# Altium schematic sheet 3xInputs.SchDoc
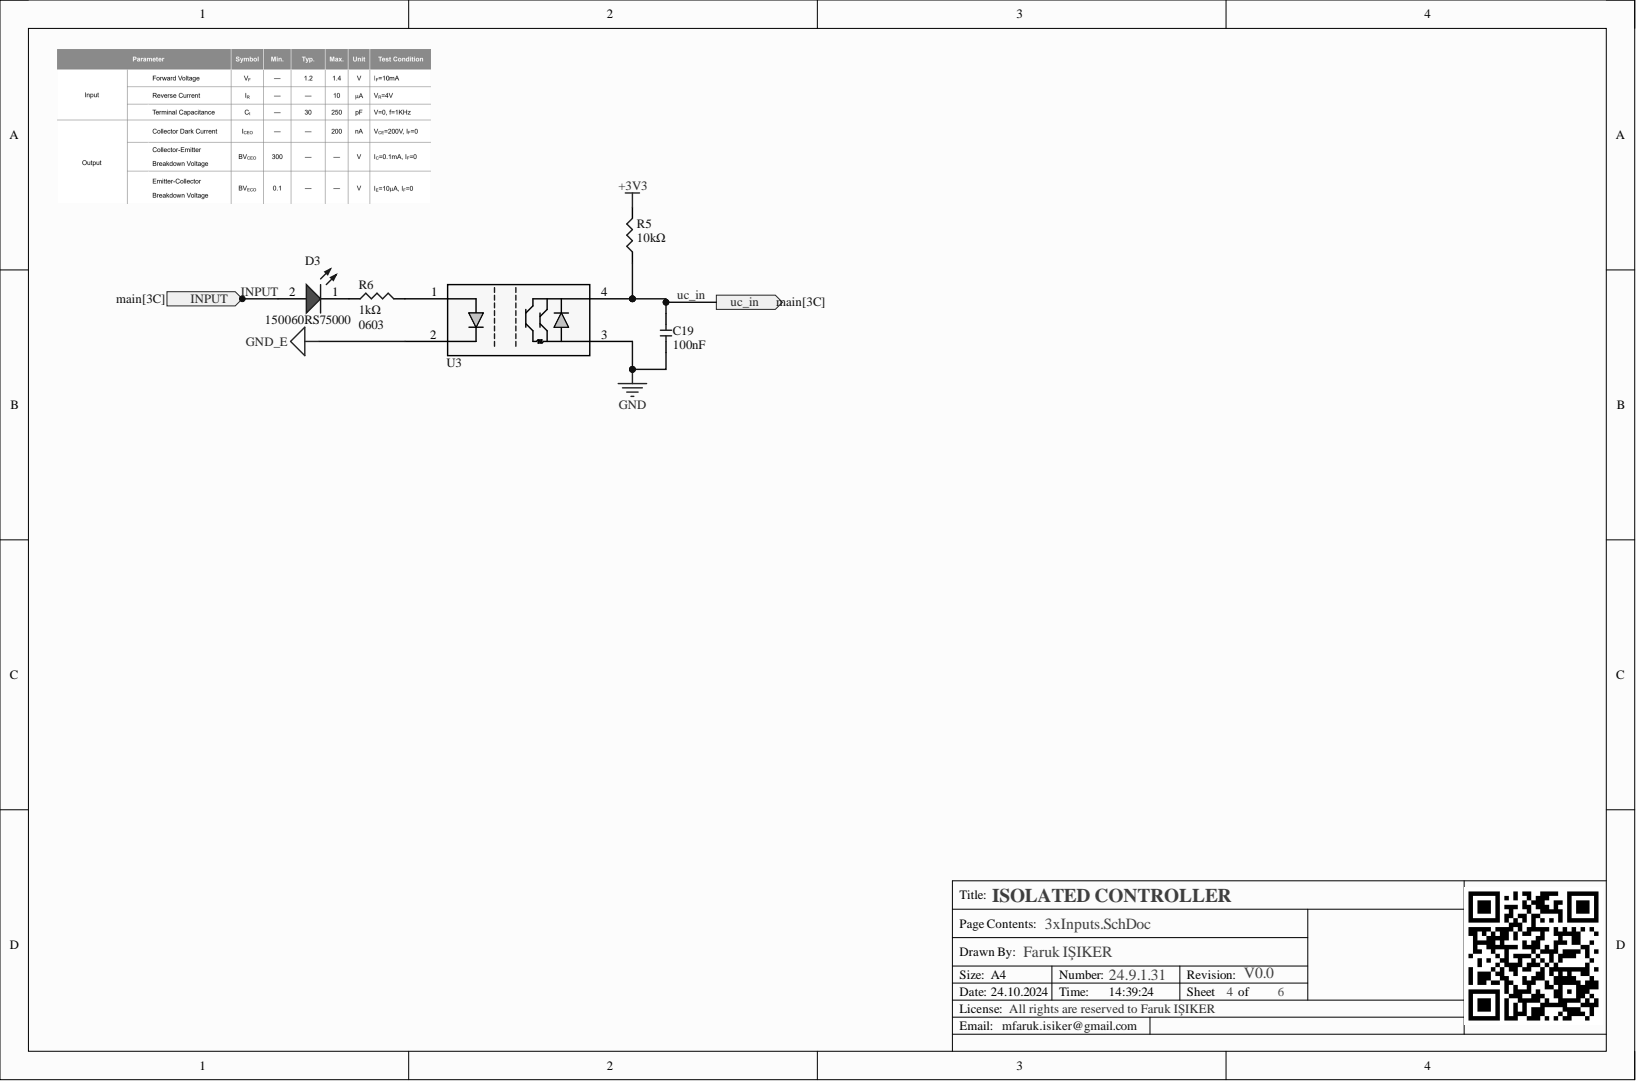
[diagram p0: 3xInputs.SchDoc]

## Parts
C19  value 100nF  [0603_cap]  (hidden comment: 0603_100nF)
D3  150060RS75000  Case Code (Imperial): 0603  [WL-SMCW_0603_150060xx75000]
R5  value 10kΩ  [0603-smd]  (hidden comment: 0603_10K)
R6  value 1kΩ  [0603-smd]  (hidden comment: 0603_1K)
U3  LTV-352T  [IC4_LTV-352T_LTO-M]  (value not drawn)

## Ports
INPUT, uc_in

## Nets
+3V3: R5.2
GND: C19.1 U3.3
GND_E: U3.2
INPUT: D3.2 ; port INPUT
uc_in: C19.2 R5.1 U3.4 ; port uc_in
NetD3_1: D3.1 R6.1
NetR6_2: R6.2 U3.1

## Library data of the parts
C19 = 0603_100nF: Volt: V; Component Kind: Standard; Library Name: generic.SchLib; Package: 0603
D3 = CMP-1426-00001-3: NexarPartId: 29717776; Color: Red; Dominant Wavelength: 625nm; Forward Current: 100mA; Forward Voltage: 2V; Lens Style: Clear, Rectangular; Luminous Intensity: 250mcd; Max Junction Temperature (Tj): 95°C; Max Reverse Voltage (DC): 5V; Peak Wavelength: 630nm; Power Dissipation: 72mW; Test Current: 20mA; Viewing Angle: 140°; Case Code (Metric): 1608; Case/Package: 0603; Component Kind: Standard; Height: 700µm; Length: 1.6mm; Library Name: Altium Content Vault; Max Operating Temperature: 85°C; Min Operating Temperature: -40°C; Mount: Surface Mount; Packaging: Tape and Reel; REACH SVHC: Unknown; Reverse Voltage: 5V; RoHS: Compliant; Wavelength: 625nm; Width: 800µm
R5 = 0603_10K: Power: W; Component Kind: Standard; Library Name: generic.SchLib; Package: 0603
R6 = 0603_1K: Power: W; Component Kind: Standard; Library Name: generic.SchLib; Package: 0603
U3 = LTV-352T: LTV Series Single Channel 300V 3750 Vrms Transistor Output Optocoupler SMT DIP-4; Manufacturer: Vishay Lite-On; Mfr_Name: LITE-ON; Category: Optocouplers; Component Kind: Standard; ComponentLink1Description: https://datasheet.ciiva.com/11772/0900766b80de6aef-11772096.pdf?src-supplier=Rs; ComponentLink1URL: https://datasheet.ciiva.com/11772/0900766b80de6aef-11772096.pdf?src-supplier=Rs; ComponentLink2Description: https://datasheet.ciiva.com/2086/ltv-352t-2086258.pdf?src-supplier=Digikey; ComponentLink2URL: https://datasheet.ciiva.com/2086/ltv-352t-2086258.pdf?src-supplier=Digikey; ComponentLink3Description: https://datasheet.ciiva.com/19471/1260710-19471302.pdf?src-supplier=Jameco; ComponentLink3URL: https://datasheet.ciiva.com/19471/1260710-19471302.pdf?src-supplier=Jameco; ComponentLink4Description: https://datasheet.ciiva.com/15118/getdatasheetpartid-145582-15118811.pdf?src-supplier=Verical; ComponentLink4URL: https://datasheet.ciiva.com/15118/getdatasheetpartid-145582-15118811.pdf?src-supplier=Verical; ComponentLink5Description: https://datasheet.ciiva.com/2086/s-110-ltv-352t20140520-2086259.pdf?src-supplier=Digikey; ComponentLink5URL: https://datasheet.ciiva.com/2086/s-110-ltv-352t20140520-2086259.pdf?src-supplier=Digikey; ComponentLink6Description: https://datasheet.ciiva.com/2086/s-110-ltv-352t20140520-2086260.pdf?src-supplier=Digikey; ComponentLink6URL: https://datasheet.ciiva.com/2086/s-110-ltv-352t20140520-2086260.pdf?src-supplier=Digikey; Library Name: generic.SchLib; Manufacturer Part Number: LTV-352T; Pricing 1: 25=0,29, 50=0,278, 250=0,228, 500=0,2 (GBP); RefDes: RefDes; RoHS: Yes; Stock 1: 22730; Supplier 1: Rs; Supplier Part Number 1: 6912063P; Type: Type
